# Altium schematic sheet DC-DC Converter.SchDoc("DC-DC Converter")
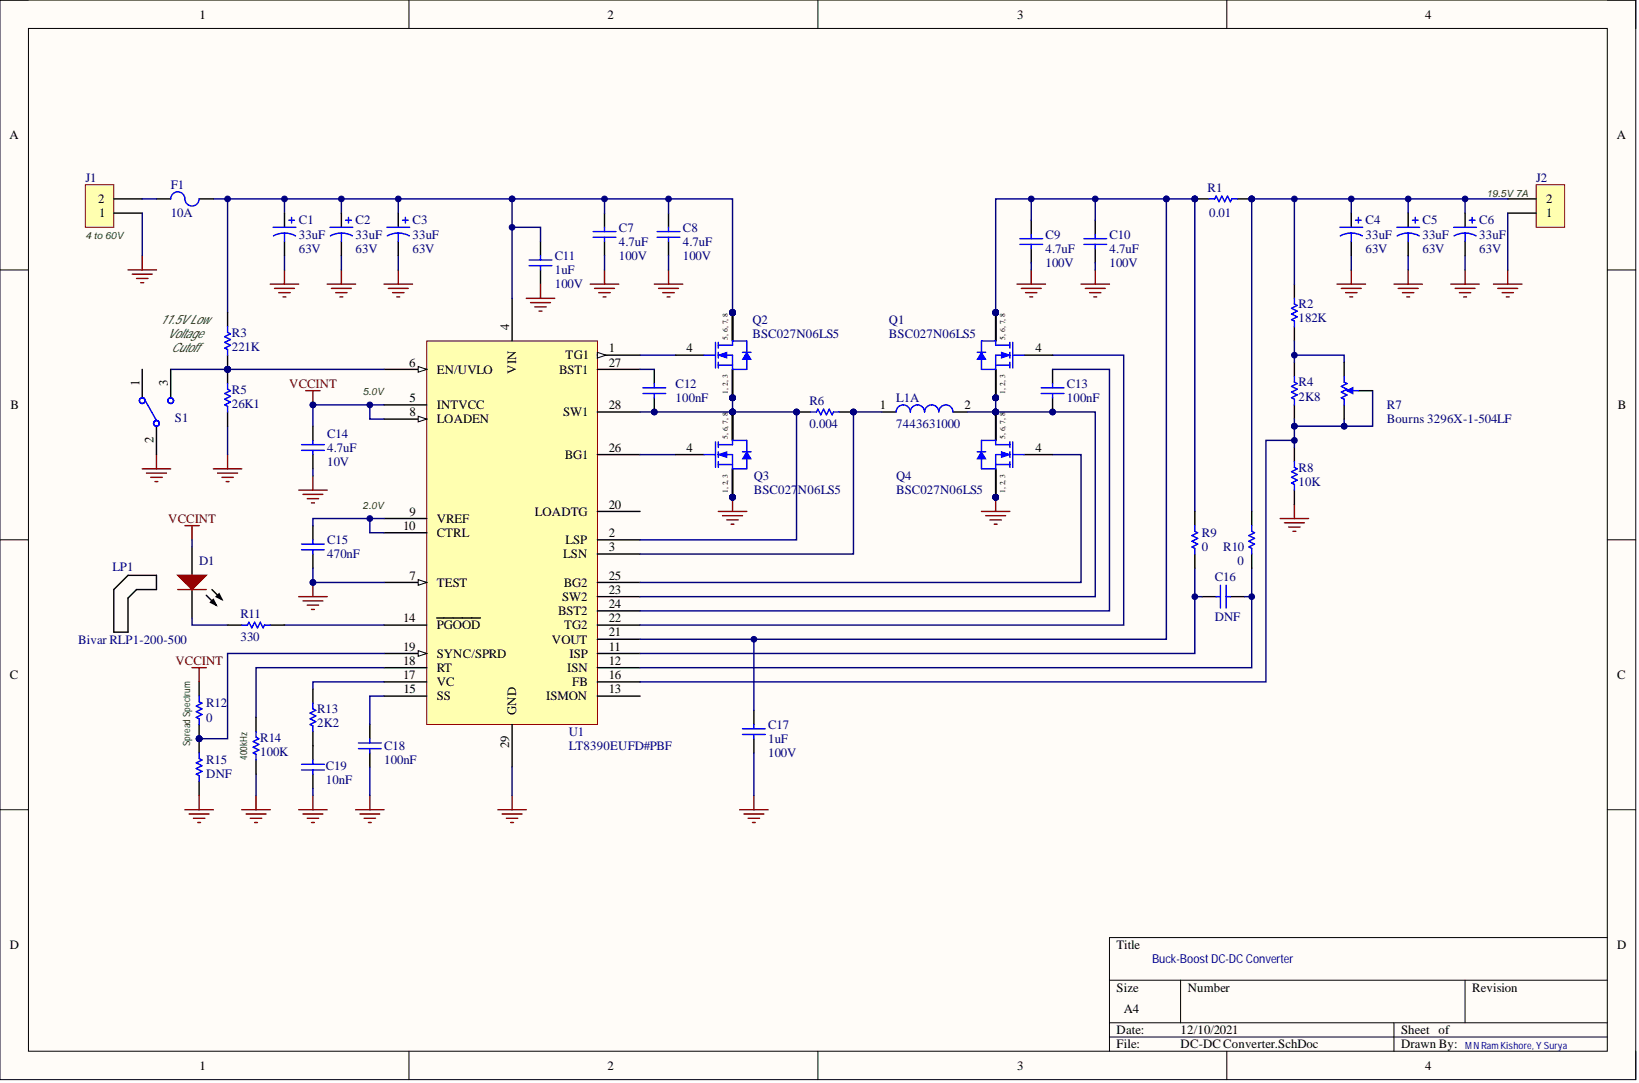
[diagram p0: DC-DC Converter.SchDoc]

## Parts
C1  33uF  Voltage Rating (DC): 63V
C2  33uF  Voltage Rating (DC): 63V
C3  33uF  Voltage Rating (DC): 63V
C4  33uF  Voltage Rating (DC): 63V
C5  33uF  Voltage Rating (DC): 63V
C6  33uF  Voltage Rating (DC): 63V
C7  4.7uF  Voltage: 100V
C8  4.7uF  Voltage: 100V
C9  4.7uF  Voltage: 100V
C10  4.7uF  Voltage: 100V
C11  1uF  Voltage Rating (DC): 100V
C12  100nF
C13  100nF
C14  4.7uF  Voltage Rating (DC): 10V
C15  470nF
C16  DNF
C17  1uF  Voltage Rating (DC): 100V
C18  100nF
C19  10nF
D1  Lite-On LTST-C170KRKT  (value not drawn)
F1  10A
J1  Input  (value not drawn)
J2  (no value)
L1  7443631000  (part A)
LP1  Bivar RLP1-200-500  Manufacturer Part Number: RLP1-200-500
Q1  BSC027N06LS5
Q2  BSC027N06LS5
Q3  BSC027N06LS5
Q4  BSC027N06LS5
R1  0.01
R2  182K
R3  221K
R4  2K8
R5  26K1
R6  0.004
R7  Bourns 3296X-1-504LF
R8  10K
R9  0
R10  0
R11  330
R12  0
R13  2K2
R14  100K
R15  DNF
S1  SW-SPDT-3_B  (value not drawn)
U1  LT8390EUFD#PBF

## Nets
GND: C1.2 C2.2 C3.2 C4.2 C5.2 C6.2 C7.1 C8.1 C9.1 C10.1 C11.1 C14.1 C15.1 C17.1 C18.1 C19.1 J1.1 J2.1 Q3.1 Q3.2 Q3.3 Q4.1 Q4.2 Q4.3 R5.1 R8.1 R14.1 R15.1 S1.2 U1.7(TEST) U1.29(GND)
VCCINT: C14.2 D1.2 R12.2 U1.5(INTVCC) U1.8(LOADEN)
NetC1_1: C1.1 C2.1 C3.1 C7.2 C8.2 C11.2 F1.2 Q2.5 Q2.6 Q2.7 Q2.8 R3.2 U1.4(VIN)
NetC4_1: C4.1 C5.1 C6.1 J2.2 R1.2 R2.2 R10.2
NetC9_2: C9.2 C10.2 C17.2 Q1.5 Q1.6 Q1.7 Q1.8 R1.1 R9.2 U1.21(VOUT)
NetC12_1: C12.1 Q2.1 Q2.2 Q2.3 Q3.5 Q3.6 Q3.7 Q3.8 R6.1 U1.2(LSP) U1.28(SW1)
NetC12_2: C12.2 U1.27(BST1)
NetC13_1: C13.1 L1.2 Q1.1 Q1.2 Q1.3 Q4.5 Q4.6 Q4.7 Q4.8 U1.23(SW2)
NetC13_2: C13.2 U1.24(BST2)
NetC15_2: C15.2 U1.9(VREF) U1.10(CTRL)
NetC16_1: C16.1 R10.1 U1.12(ISN)
NetC16_2: C16.2 R9.1 U1.11(ISP)
NetC18_2: C18.2 U1.15(SS)
NetC19_2: C19.2 R13.1
NetD1_1: D1.1 R11.2
NetF1_1: F1.1 J1.2
NetL1_1: L1.1 R6.2 U1.3(LSN)
NetQ1_4: Q1.4 U1.22(TG2)
NetQ2_4: Q2.4 U1.1(TG1)
NetQ3_4: Q3.4 U1.26(BG1)
NetQ4_4: Q4.4 U1.25(BG2)
NetR2_1: R2.1 R4.2 R7.3
NetR3_1: R3.1 R5.2 S1.3 U1.6(EN/UVLO)
NetR4_1: R4.1 R7.1 R7.2 R8.2 U1.16(FB)
NetR11_1: R11.1 U1.14(PGOOD)
NetR12_1: R12.1 R15.2 U1.19(SYNC/SPRD)
NetR13_2: R13.2 U1.17(VC)
NetR14_2: R14.2 U1.18(RT)

Not connected: S1 1; U1 13(ISMON) 20(LOADTG)

## Library data of the parts
C1-C6 = CMP-7693bfcb6deba954-3: Cap Aluminum 33uF 63V 20% (8 X 10.2mm) SMD 250mA 2000h 105 C T/R; Manufacturer Part Number: EEEFK1J330P; Capacitance: 33.0 µF; Dielectric Material: Aluminum Electrolytic; Component Kind: Standard; Library Name: CommunityVault; Lifecycle Status: Not Listed by Manufacturer; Mounting Style: Surface Mount; Number of Pins: 2; Octopart URL: https://octopart.com/eee-fk1j330p-panasonic-39481025; Operating Temperature: 105 °C (max); Packaging: Tape & Reel (TR), Cut Tape (CT); REACH SVHC Compliance: No SVHC; Size-Diameter: 8.00 mm; Size-Height: 10.2 mm; Size-Width: 10.0 mm
C7-C10 = CMP-cbb5062a85501472-2: Cap , Midhigh Vol, 1210, 100v, X7s, 4.7uf20% , t&r; Manufacturer Part Number: HMK325C7475MM-PE; Capacitance: 4.7uF; Component Kind: Standard; Dielectric Characteristic: X7S; Library Name: CommunityVault
C11 C17 = CMP-127163-3: CAP CER 1UF 100V 10% X7R 1210; Manufacturer Part Number: 12101C105KAT2A; Capacitance: 1e-06 F; Dielectric Material: Ceramic Multilayer; Lead-Free Status: Lead Free; Capacitance Tolerance: ±10%; Case/Package: 1210; Component Kind: Standard; Dielectric Characteristic: X7R; Library Name: CommunityVault; Mounting Style: Surface Mount; Number of Pins: 2; Octopart Url: https://octopart.com/12101c105kat2a-avx+interconnect+%2F+elco-39513114; OctopartID: 9d625632541e42a5; REACH SVHC Compliance: No SVHC; RoHS: Compliant; Size-Height: 0.00279 m; Size-Length: 0.0032 m; Size-Width: 0.0025 m
C12 C13 C18 = CMP-54a29ee556ae8b65-2: Capacitor; Ceramic; Cap 0.1 uF; Tol 5%; Vol-Rtg 50 VDC; SMT; 0805; X7R; Tape & Reel; Manufacturer Part Number: 08055C104JAT2A; Case/Package (SI): 2012; Insulation Resistance: 100 GΩ; Lead-Free Status: Lead Free; Voltage Rating (DC): 50.0 V; Capacitance: 100 nF; Capacitance Tolerance: ±5%; Case/Package: 0805; Component Kind: Standard; Dielectric Characteristic: X7R; Library Name: CommunityVault; Mounting Style: Surface Mount; Number of Pins: 2; Octopart URL: http://octopart.com/08055c104jat2a-avx-55388010; OctopartID: 54a29ee556ae8b65; Packaging: Tape & Reel (TR), Cut Tape (CT); REACH SVHC Compliance: No SVHC; RoHS: Compliant; Size-Height: 710 µm; Size-Length: 2.01 mm; Size-Thickness: 940 µm; Size-Width: 1.24 mm
C14 = CMP-126761-3: CAP CER 4.7UF 10V 10% X5R 0805; Manufacturer Part Number: 0805ZD475KAT2A; Capacitance: 4.7e-06 F; Case/Package (SI): 2012; Dielectric Material: Ceramic Multilayer; Lead-Free Status: Lead Free; Capacitance Tolerance: ±10%; Case/Package: 0805; Component Kind: Standard; Dielectric Characteristic: X5R; Library Name: CommunityVault; Mounting Style: Surface Mount; Number of Pins: 2; Octopart Url: https://octopart.com/0805zd475kat2a-avx+interconnect+%2F+elco-39513109; OctopartID: 520399539b708dc4; REACH SVHC Compliance: No SVHC; RoHS: Compliant; Size-Height: 0.0014 m; Size-Length: 0.00201 m; Size-Width: 0.00125 m
C15 = CMP-3555014-4: Multilayer Ceramic Capacitors MLCC - SMD/SMT .47uF 50v 20% Tol.; Manufacturer Part Number: 08055C474JAT2A; Capacitance: 4.7e-07 F; Capacitance Tolerance: ±5%; Dielectric Characteristic: X7R; Lead-Free Status: Lead Free; Voltage Rating (DC): 50.0 V; Case/Package: 0805; Component Kind: Standard; Library Name: CommunityVault; Mounting Style: Surface Mount; Number of Pins: 2; Octopart Url: https://octopart.com/08055c474jat2a-avx+interconnect+%2F+elco-8665314; OctopartID: 179bde150a1fc85c; RoHS: Compliant
C16 = CMP-54a29ee556ae8b65-2: Capacitor; Ceramic; Cap 0.1 uF; Tol 5%; Vol-Rtg 50 VDC; SMT; 0805; X7R; Tape & Reel; Manufacturer Part Number: 08055C104JAT2A; Capacitance: 100 nF; Case/Package (SI): 2012; Insulation Resistance: 100 GΩ; Lead-Free Status: Lead Free; Voltage Rating (DC): 50.0 V; Capacitance Tolerance: ±5%; Case/Package: 0805; Component Kind: Standard; Dielectric Characteristic: X7R; Library Name: CommunityVault; Mounting Style: Surface Mount; Number of Pins: 2; Octopart URL: http://octopart.com/08055c104jat2a-avx-55388010; OctopartID: 54a29ee556ae8b65; Packaging: Tape & Reel (TR), Cut Tape (CT); REACH SVHC Compliance: No SVHC; RoHS: Compliant; Size-Height: 710 µm; Size-Length: 2.01 mm; Size-Thickness: 940 µm; Size-Width: 1.24 mm
C19 = CMP-16466678-4: cap smd 0805; Manufacturer Part Number: 08055C103JAT2A; Capacitance: 1e-08 F; Capacitance Tolerance: ±5%; Case/Package (SI): 2012; Dielectric Characteristic: X7R; Dielectric Material: Ceramic Multilayer; Insulation Resistance: 1e+11 Ω; Lead-Free Status: Lead Free; Voltage Rating (DC): 50.0 V; Case/Package: 0805; Component Kind: Standard; Library Name: CommunityVault; Mounting Style: Surface Mount; Number of Pins: 2; Octopart Url: https://octopart.com/08055c103jat2a-avx+interconnect+%2F+elco-39513106; OctopartID: 02fac9aacb8edd3d; REACH SVHC Compliance: No SVHC; RoHS: Compliant; Size-Height: 0.00094 m; Size-Length: 0.0020066 m; Size-Thickness: 0.0009398 m; Size-Width: 0.0012446 m
D1 = CMP-1544870-4: Red 2 x 1.25 x 1.1 mm 130° Water Clear 54 mcd 2 V LED Surface Mount; Case/Package (SI): 2012; Forward Voltage: 2.00 V; Lead-Free Status: Lead Free; Lens Type: Non-Diffused; Luminous Intensity: 54.0 mcd; Wavelength: 639 nm; Case/Package: 0805; Color: Red; Component Kind: Standard; Library Name: CommunityVault; Manufacturer Part Number: LTST-C170KRKT; Mounting Style: Surface Mount, Vertical; Octopart URL: http://octopart.com/ltst-c170krkt-lite-on-549878; OctopartID: 1d24d4265bb4c2e3; Packaging: Cut Tape (CT), Tape & Reel (TR); RoHS: Compliant; Size-Height: 1.10 mm; Size-Length: 2.00 mm; Size-Width: 1.25 mm; Viewing Angle: 130 °
F1 = CMP-254385-4: 154 Series Fast-Acting 125 V 10 A OMNI-BLOK® Surface Mount Fuse & Holder; Manufacturer Part Number: 0154010.DR; Color: White; Current Rating: 10.0 A; Lead-Free Status: Lead Free; Voltage Rating (AC): 125 V; Component Kind: Standard; Flammability Rating: UL 94 V-0; Library Name: CommunityVault; Mounting Style: Surface Mount, PCB; Octopart URL: http://octopart.com/0154010.dr-littelfuse-565890; OctopartID: 0e9fc79d897bd158; Packaging: Cut Tape (CT); REACH SVHC Compliance: No SVHC; RoHS: Compliant; Size-Height: 3.81 mm; Size-Length: 9.73 mm; Size-Width: 5.03 mm; Voltage Rating (DC): 125 V
J1 J2 = CMP-0680cf8a1162d947-2: Phoenix 1757242 MSTBA 2.5/ 2-G-5.08 Base Strip/Header 4 Kilo-Volt Surge 320 Volt Rated III/2 12 Amp Green Plug-In; Current Rating: 12.0 A; Gender: Male; Lead-Free Status: Lead Free; Mounting Angle: 90.0 °; Number of Contacts: 2; Pin Pitch: 0.00508 m; Voltage Rating (DC): 320.0 V; Color: Green; Component Kind: Standard; Flammability Rating: UL 94 V-0; Housing Color: Green; Housing Material: Plastic; Manufacturer Part Number: 1757242; Mounting Style: PCB, Through Hole, Solder; Number of Positions: 2; Number of Rows: 1; Octopart URL: http://octopart.com/1757242-phoenix+contact-12932; OctopartID: 0680cf8a1162d947; REACH SVHC Compliance: No SVHC; RoHS: Compliant; Size-Height: 0.0086 m; Size-Length: 0.01216 m; Size-Width: 0.00508 m
  J1: Library Name: FavoritesLibrary
  J2: Library Name: CommunityVault
L1 = CMP-913017-2: SMD High Current Inductor WE-HCF, L=10.0 µH; Inductance: 1e-05 H; Resistance: 0.00796 Ω; Resonant Frequency: 13000000.0 Hz; Component Kind: Standard; Library Name: CommunityVault; Manufacturer Part Number: 7443631000; Number of Pins: 3; Octopart Url: https://octopart.com/7443631000-w%C3%BCrth+elektronik-19122473; OctopartID: 0320cb09ef6fdce7; Packaging: Cut Tape (CT); REACH SVHC Compliance: No SVHC; RoHS: Compliant; Self Resonant Frequency: 13000000.0 Hz
LP1 = CMP-b0a0789a751f6f67-3: Single Station; 2.8mm; Enhanced Rigid Light Pipe; 5.0mm Elevation; 14.0mm Protrusion; Lead-Free Status: Lead Free; Color: White; Component Kind: Standard; Library Name: CommunityVault; Mounting Style: Surface Mount; Octopart URL: http://octopart.com/rlp1-200-500-bivar-19980505; Size-Length: 14.0 mm
Q1-Q4 = CMP-9dc451c8cc734203-2: N-Channel OptiMOS5 Power Transistor, 60V VDS, 100A ID, PG-TDSON-8-1; Manufacturer Part Number: BSC027N06LS5ATMA1; Component Kind: Standard; Library Name: CommunityVault; OctopartID: 9dc451c8cc734203
R1 = CMP-3ffef57a43e1e039-1: Res Metal Alloy 2512 10m Ohm 1% 2W ±75ppm/°C Molded SMD Embossed Carrier T/R; Manufacturer Part Number: ERJ-MS4HF10MU; Power Rating: 2.00 W; Resistance: 10.0 m?; Component Kind: Standard; Library Name: FavoritesLibrary; Lifecycle Status: Not Listed by Manufacturer; Octopart URL: http://octopart.com/erj-ms4hf10mu-panasonic-59660665; OctopartID: 3ffef57a43e1e039; Packaging: Cut Tape (CT); Resistance Tolerance: ±1%; RoHS: Compliant
R2 = CMP-1854500-2: RES SMD 182K OHM 1% 1/8W 0805; Manufacturer Part Number: RC0805FR-07182KL; Case/Package (SI): 2012; Lead-Free Status: Lead Free; Power Rating: 0.125 W; Resistance: 182000.0 Ω; Voltage Rating (DC): 150.0 V; Case/Package: 0805; Component Kind: Standard; Library Name: CommunityVault; Mounting Style: Surface Mount; Octopart Url: https://octopart.com/rc0805fr-07182kl-yageo-1246310; OctopartID: 0628cf312728d9ef; Resistance Tolerance: ±1%; RoHS: Compliant; Size-Height: 0.0005 m; Size-Length: 0.002 m; Size-Width: 0.00125 m
R3 = CMP-3600ff19ac10e9c7-1: Res Thick Film 0805 221K Ohm 1% 0.125W(1/8W) ±100ppm/C Epoxy Pad SMD T/R; Manufacturer Part Number: RC0805FR-07221KL; Case/Package (SI): 2012; Lead-Free Status: Lead Free; Power Rating: 125 mW; Resistance: 221 k?; Temperature Coefficient: -100 ppm/°C to 100 ppm/°C; Voltage Rating (DC): 150 V; Case/Package: 0805; Component Kind: Standard; Library Name: CommunityVault; Mounting Style: Surface Mount; Octopart URL: https://octopart.com/rc0805fr-07221kl-yageo-1294198; OctopartID: 3600ff19ac10e9c7; Operating Temperature: 155 °C (max); Packaging: Tape & Reel; Resistance Tolerance: ±1%; RoHS: Compliant; Size-Height: 500 µm; Size-Length: 2.00 mm; Size-Width: 1.25 mm
R4 = CMP-1854595-2: RES SMD 2.8K OHM 1% 1/8W 0805; Manufacturer Part Number: RC0805FR-072K8L; Case/Package (SI): 2012; Lead-Free Status: Lead Free; Power Rating: 0.125 W; Resistance: 2800.0 Ω; Voltage Rating (DC): 150.0 V; Case/Package: 0805; Component Kind: Standard; Library Name: CommunityVault; Mounting Style: Surface Mount; Octopart Url: https://octopart.com/rc0805fr-072k8l-yageo-1182410; OctopartID: 2952e04a06fef695; Resistance Tolerance: ±1%; RoHS: Compliant; Size-Height: 0.0005 m; Size-Length: 0.002 m; Size-Width: 0.00125 m
R5 = CMP-ab5460010ab47493-2: Res Thick Film 2.0 x 1.2 mm 26.1K Ohm 1% 0.125W(1/8W) 100ppm/ C Molded SMD Paper T/R; Manufacturer Part Number: RC0805FR-0726K1L; Case/Package (SI): 2012; Power Rating: 125 mW; Temperature Coefficient: -100 ppm/°C to 100 ppm/°C; Voltage Rating (DC): 150 V; Case/Package: 0805; Component Kind: Standard; Library Name: CommunityVault; Mounting Style: Surface Mount; OctopartID: ab5460010ab47493; Operating Temperature: 155 °C (max)
R6 = CMP-c31e099abffa1cd9-1: Current sense resistor [Panasonic Automotive & Industrial Systems] ERJMS4SF4M0U Current sense resistor; Manufacturer Part Number: ERJ-MS4SF4M0U; Resistance: 4.00 mΩ; Resistance Tolerance: ±1%; Component Kind: Standard; Library Name: CommunityVault; Lifecycle Status: Not Listed by Manufacturer; Octopart URL: http://octopart.com/erj-ms4sf4m0u-panasonic-59660674; OctopartID: c31e099abffa1cd9; Packaging: Cut Tape (CT); RoHS: Compliant
R7 = CMP-793ab03899fca6fd-3: Res Cermet Trimmer 500K Ohm 10% 1/2W 25(Elec)Turn 2.19mm (11.05 X 4.83 X 10.03mm) Pin Thru-Hole Tube; Voltage Rating (dc): 300 V; Component Kind: Standard; Library Name: CommunityVault; Manufacturer Part Number: 3296X-1-504LF; Resistance: 500K; Resistance Tolerance: 10%
R8 = CMP-1854303-12: RC Series 0805 0.125 W 10 kOhms 1% ±100 ppm/°C SMT Thick Film Chip Resistor; Manufacturer Part Number: RC0805FR-0710KL; Case/Package (SI): 2012; Lead-Free Status: Lead Free; Power Rating: 0.125 W; Resistance: 10000.0 Ω; Voltage Rating (DC): 150.0 V; Case/Package: 0805; Component Kind: Standard; Library Name: CommunityVault; Mounting Style: Surface Mount; Number of Pins: 2; Octopart Url: http://octopart.com/rc0805fr-0710kl-yageo-40301103; OctopartID: d971121d0e3806b6; REACH SVHC Compliance: No SVHC; Resistance Tolerance: ±1%; RoHS: Compliant; Seller Landing  Url: https://octopart-clicks.com/click/track?country=US&ak=8157f166&sig=02da2a9&sid=5489&ppid=40301103&vpid=114937402&ct=offers; Size-Height: 0.0005 m; Size-Length: 0.002 m; Size-Width: 0.00125 m
R9 R10 R12 R15 = CMP-1875921-8: Res Thick Film 0805 0 Ohm Molded SMD Paper T/R; Manufacturer Part Number: RC0805FR-070RL; Lead-Free Status: Lead Free; Resistance: 0.0 Ω; Resistance Tolerance: ±1%; Case/Package: 0805; Component Kind: Standard; Library Name: CommunityVault; Mounting Style: Surface Mount; Octopart Url: https://octopart.com/rc0805fr-070rl-yageo-9293404; OctopartID: f3d13a5618eb2c16; RoHS: Compliant
R11 = CMP-e8e92397713d372e-2: RC Series 0805 0.125 W 330 Ohms 1% ±100 ppm/°C SMT Thick Film Chip Resistor; Manufacturer Part Number: RC0805FR-07330RL; Case/Package (SI): 2012; Lead-Free Status: Lead Free; Power Rating: 125 mW; Resistance: 330 Ω; Voltage Rating (DC): 150 V; Case/Package: 0805; Component Kind: Standard; Library Name: CommunityVault; Mounting Style: Surface Mount; Number of Pins: 2; Octopart URL: http://octopart.com/rc0805fr-07330rl-yageo-41204914; OctopartID: e8e92397713d372e; Packaging: Cut Tape (CT), Reel; REACH SVHC Compliance: No SVHC; Resistance Tolerance: ±1%; RoHS: Compliant; Size-Height: 500 µm; Size-Length: 2.00 mm; Size-Width: 1.25 mm
R13 = CMP-18c6d3bcd80fc701-3: Res Thick Film 0805 2.2K Ohm 1% 0.125W(1/8W) 100ppm/ Molded SMD Paper T/R; Manufacturer Part Number: RC0805FR-072K2L; Case/Package (SI): 2012; Lead-Free Status: Lead Free; Power Rating: 125 mW; Resistance: 2.20 kΩ; Voltage Rating (DC): 150 V; Case/Package: 0805; Component Kind: Standard; Library Name: CommunityVault; Mounting Style: Surface Mount; Octopart URL: http://octopart.com/rc0805fr-072k2l-yageo-40953263; REACH SVHC Compliance: No SVHC; RoHS: Compliant; Size-Height: 500 µm; Size-Length: 2.00 mm
R14 = CMP-1854318-18: Res Thick Film 0805 100K Ohm 1% 0.125W(1/8W} 100ppm/Molded SMD Paper T/R; Manufacturer Part Number: RC0805FR-07100KL; Component Kind: Standard; Library Name: CommunityVault
S1 = CMP-d175186fcc2b0eb2-2: 200 Series SPDT On-None-On Right Angle Sub-Miniature Toggle Switch; Manufacturer Part Number: 200MSP1T2B2M7RE; Actuator Type: Standard; Current Rating: 400 mA; Lead-Free Status: Lead Free; Component Kind: Standard; Contacts Type: SPDT; Library Name: CommunityVault; Mounting Style: Through Hole, Vertical, PC Board; Orientation: Vertical; RoHS: Compliant; Size-Length: 8.13 mm; Size-Width: 6.10 mm
U1 = CMP-ac1b61931e1b73dc-2: LT8390 - 60V Synchronous 4-Switch Buck-Boost Controller with Spread Spectrum; Case/Package: QFN; Component Kind: Standard; Library Name: CommunityVault; Manufacturer Part Number: LT8390EUFD#PBF; Octopart URL: http://octopart.com/lt8390eufd%23pbf-linear+technology-75667302; OctopartID: ac1b61931e1b73dc; RoHS: Compliant
